# Altium schematic sheet 4_FPGA_PWR.SchDoc(4_FPGA_PWR)
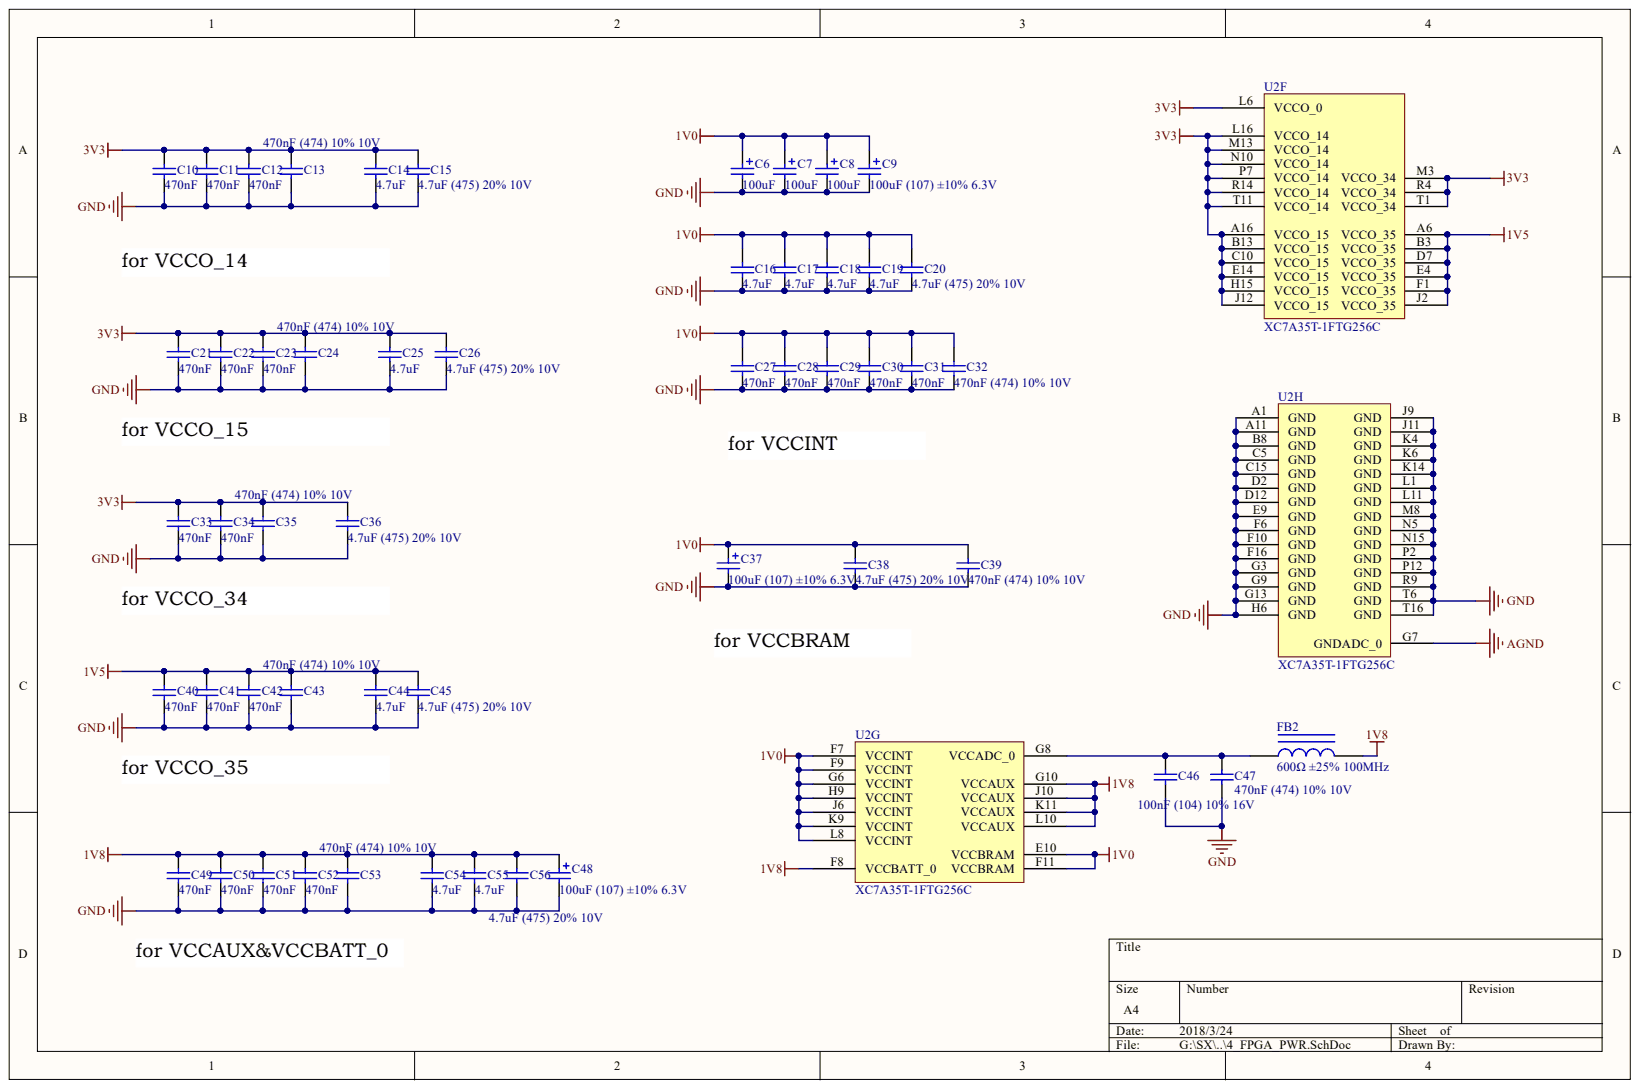
[diagram p0: 4_FPGA_PWR.SchDoc]

## Parts
C6  100uF  [CASE-B_3528]
C7  100uF  [CASE-B_3528]
C8  100uF  [CASE-B_3528]
C9  100uF (107) ±10% 6.3V  [CASE-B_3528]
C10  470nF  [C0402_BGA]
C11  470nF  [C0402_BGA]
C12  470nF  [C0402_BGA]
C13  470nF (474) 10% 10V  [C0402_BGA]
C14  4.7uF  [C0402_BGA]
C15  4.7uF (475) 20% 10V  [C0402_BGA]
C16  4.7uF  [C0402_BGA]
C17  4.7uF  [C0402_BGA]
C18  4.7uF  [C0402_BGA]
C19  4.7uF  [C0402_BGA]
C20  4.7uF (475) 20% 10V  [C0402_BGA]
C21  470nF  [C0402_BGA]
C22  470nF  [C0402_BGA]
C23  470nF  [C0402_BGA]
C24  470nF (474) 10% 10V  [C0402_BGA]
C25  4.7uF  [C0402_BGA]
C26  4.7uF (475) 20% 10V  [C0402_BGA]
C27  470nF  [C0402_BGA]
C28  470nF  [C0402_BGA]
C29  470nF  [C0402_BGA]
C30  470nF  [C0402_BGA]
C31  470nF  [C0402_BGA]
C32  470nF (474) 10% 10V  [C0402_BGA]
C33  470nF  [C0402_BGA]
C34  470nF  [C0402_BGA]
C35  470nF (474) 10% 10V  [C0402_BGA]
C36  4.7uF (475) 20% 10V  [C0402_BGA]
C37  100uF (107) ±10% 6.3V  [CASE-B_3528]
C38  4.7uF (475) 20% 10V  [C0402_BGA]
C39  470nF (474) 10% 10V  [C0402_BGA]
C40  470nF  [C0402_BGA]
C41  470nF  [C0402_BGA]
C42  470nF  [C0402_BGA]
C43  470nF (474) 10% 10V  [C0402_BGA]
C44  4.7uF  [C0402_BGA]
C45  4.7uF (475) 20% 10V  [C0402_BGA]
C46  100nF (104) 10% 16V  [0402_C]
C47  470nF (474) 10% 10V  [0402_C]
C48  100uF (107) ±10% 6.3V  [CASE-B_3528]
C49  470nF  [C0402_BGA]
C50  470nF  [C0402_BGA]
C51  470nF  [C0402_BGA]
C52  470nF  [C0402_BGA]
C53  470nF (474) 10% 10V  [C0402_BGA]
C54  4.7uF  [C0402_BGA]
C55  4.7uF  [C0402_BGA]
C56  4.7uF (475) 20% 10V  [C0402_BGA]
FB2  600Ω ±25% 100MHz  [0805_L]
U2  XC7A35T-1FTG256C  [FTG256]  (parts F,G,H)

## Nets
1V0: C6.1 C7.1 C8.1 C9.1 C16.2 C17.2 C18.2 C19.2 C20.2 C27.2 C28.2 C29.2 C30.2 C31.2 C32.2 C37.1 C38.2 C39.2 U2.E10(VCCBRAM) U2.F7(VCCINT) U2.F9(VCCINT) U2.F11(VCCBRAM) U2.G6(VCCINT) U2.H9(VCCINT) U2.J6(VCCINT) U2.K9(VCCINT) U2.L8(VCCINT)
1V5: C40.2 C41.2 C42.2 C43.2 C44.2 C45.2 U2.A6(VCCO_35) U2.B3(VCCO_35) U2.D7(VCCO_35) U2.E4(VCCO_35) U2.F1(VCCO_35) U2.J2(VCCO_35)
1V8: C48.1 C49.2 C50.2 C51.2 C52.2 C53.2 C54.2 C55.2 C56.2 FB2.2 U2.F8(VCCBATT_0) U2.G10(VCCAUX) U2.J10(VCCAUX) U2.K11(VCCAUX) U2.L10(VCCAUX)
3V3: C10.2 C11.2 C12.2 C13.2 C14.2 C15.2 C21.2 C22.2 C23.2 C24.2 C25.2 C26.2 C33.2 C34.2 C35.2 C36.2 U2.A16(VCCO_15) U2.B13(VCCO_15) U2.C10(VCCO_15) U2.E14(VCCO_15) U2.H15(VCCO_15) U2.J12(VCCO_15) U2.L6(VCCO_0) U2.L16(VCCO_14) U2.M3(VCCO_34) U2.M13(VCCO_14) U2.N10(VCCO_14) U2.P7(VCCO_14) U2.R4(VCCO_34) U2.R14(VCCO_14) U2.T1(VCCO_34) U2.T11(VCCO_14)
AGND: U2.G7(GNDADC_0)
GND: C6.2 C7.2 C8.2 C9.2 C10.1 C11.1 C12.1 C13.1 C14.1 C15.1 C16.1 C17.1 C18.1 C19.1 C20.1 C21.1 C22.1 C23.1 C24.1 C25.1 C26.1 C27.1 C28.1 C29.1 C30.1 C31.1 C32.1 C33.1 C34.1 C35.1 C36.1 C37.2 C38.1 C39.1 C40.1 C41.1 C42.1 C43.1 C44.1 C45.1 C46.1 C47.1 C48.2 C49.1 C50.1 C51.1 C52.1 C53.1 C54.1 C55.1 C56.1 U2.A1(GND) U2.A11(GND) U2.B8(GND) U2.C5(GND) U2.C15(GND) U2.D2(GND) U2.D12(GND) U2.E9(GND) U2.F6(GND) U2.F10(GND) U2.F16(GND) U2.G3(GND) U2.G9(GND) U2.G13(GND) U2.H6(GND) U2.J9(GND) U2.J11(GND) U2.K4(GND) U2.K6(GND) U2.K14(GND) U2.L1(GND) U2.L11(GND) U2.M8(GND) U2.N5(GND) U2.N15(GND) U2.P2(GND) U2.P12(GND) U2.R9(GND) U2.T6(GND) U2.T16(GND)
NetC46_2: C46.2 C47.2 FB2.1 U2.G8(VCCADC_0)

## Library data of the parts
C6-C9 C37 C48 = 3528 100uF (107) 10% 6.3V: 钽电容; Component Kind: Standard; ComponentLink1Description: 供应商链接; ComponentLink1URL: http://www.szlcsc.com/product/details_16815.html; Library Name: Miscellaneous Devices LC.IntLib; Package: 3528; Supplier: LC; SuppliersPartNumber: C16133
C10-C13 C21-C24 C27-C35 C39-C43 C47 C49-C53 = 0402 470nF (474) 10% 10V: 贴片电容; Component Kind: Standard; ComponentLink1Description: 供应商链接; ComponentLink1URL: http://www.szlcsc.com/product/details_48344.html; Library Name: Miscellaneous Devices LC.IntLib; Package: 0402; Supplier: LC; SuppliersPartNumber: C47339
C14-C20 C25 C26 C36 C38 C44 C45 C54-C56 = 0402 4.7uF (475) 20% 10V: 贴片电容; Component Kind: Standard; ComponentLink1Description: 供应商链接; ComponentLink1URL: http://www.szlcsc.com/product/details_24469.html; Library Name: Miscellaneous Devices LC.IntLib; Package: 0402; Supplier: LC; SuppliersPartNumber: C23733
C46 = 0402 100nF (104) 10% 16V: 贴片电容; Component Kind: Standard; ComponentLink1Description: 供应商链接; ComponentLink1URL: http://www.szlcsc.com/product/details_1877.html; Library Name: Miscellaneous Devices LC.IntLib; Package: 0402; Supplier: LC; SuppliersPartNumber: C1525
FB2 = 0805 600Ω 25% 100MHz: 贴片磁珠; Component Kind: Standard; ComponentLink1Description: 供应商链接; ComponentLink1URL: http://www.szlcsc.com/product/details_1369.html; Library Name: Miscellaneous Devices LC.IntLib; Package: 0805; Supplier: LC; SuppliersPartNumber: C1017
U2 = XC7A35T-1FTG256C: Artix-7 FPGA, 170 User I/Os, 0 GTP, 256-Ball BGA, Speed Grade 1, Commercial Grade, Pb-Free; Manufacturer: Xilinx; Available User I/O: 170; Clock Mgmt Tiles (CMTs): 5; GTP: 0; Logic Cells: 33280; Speed Grades: 1; Total Block RAM: 1800 Kb; Component Kind: Standard; ComponentLink1Description: Manufacturer URL; ComponentLink1URL: http://www.xilinx.com/; ComponentLink2Description: Datasheet; ComponentLink2URL: http://www.xilinx.com/support/documentation/data_sheets/ds180_7Series_Overview.pdf; ComponentLink3Description: Package Specification; ComponentLink3URL: http://www.xilinx.com/support/documentation/user_guides/ug475_7Series_Pkg_Pinout.pdf; ComponentLink4Description: DC and Switching Characteristics; ComponentLink4URL: http://www.xilinx.com/support/documentation/data_sheets/ds181_Artix_7_Data_Sheet.pdf; ComponentLink5Description: Package File; ComponentLink5URL: http://www.xilinx.com/support/packagefiles/a7packages/xc7a200tsbg484pkg.txt; ComponentLink6Description: Packaging and Pinout; ComponentLink6URL: http://www.xilinx.com/support/documentation/user_guides/ug475_7Series_Pkg_Pinout.pdf; DatasheetVersion: v1.15; Library Name: Xilinx Artix-7.SchLib; Maximum Distributed RAM: 400 Kb; Mounting Technology: Surface Mount; NexusDeviceID: XC7A35T-1FTG256C; Operating Temperature Range: Commercial; PackageDescription: 256-Ball Wire-Bond Fine-Pitch Thin BGA, Body 17 x 17 mm, Pitch 1 mm; PackageReference: FTG-256; PackageVersion: Rev. 1.11; PartNumber: XC7A35T-1FTG256C
